# Altium schematic sheet ExoIMU_Connector.SchDoc(ExoIMU_Connector)
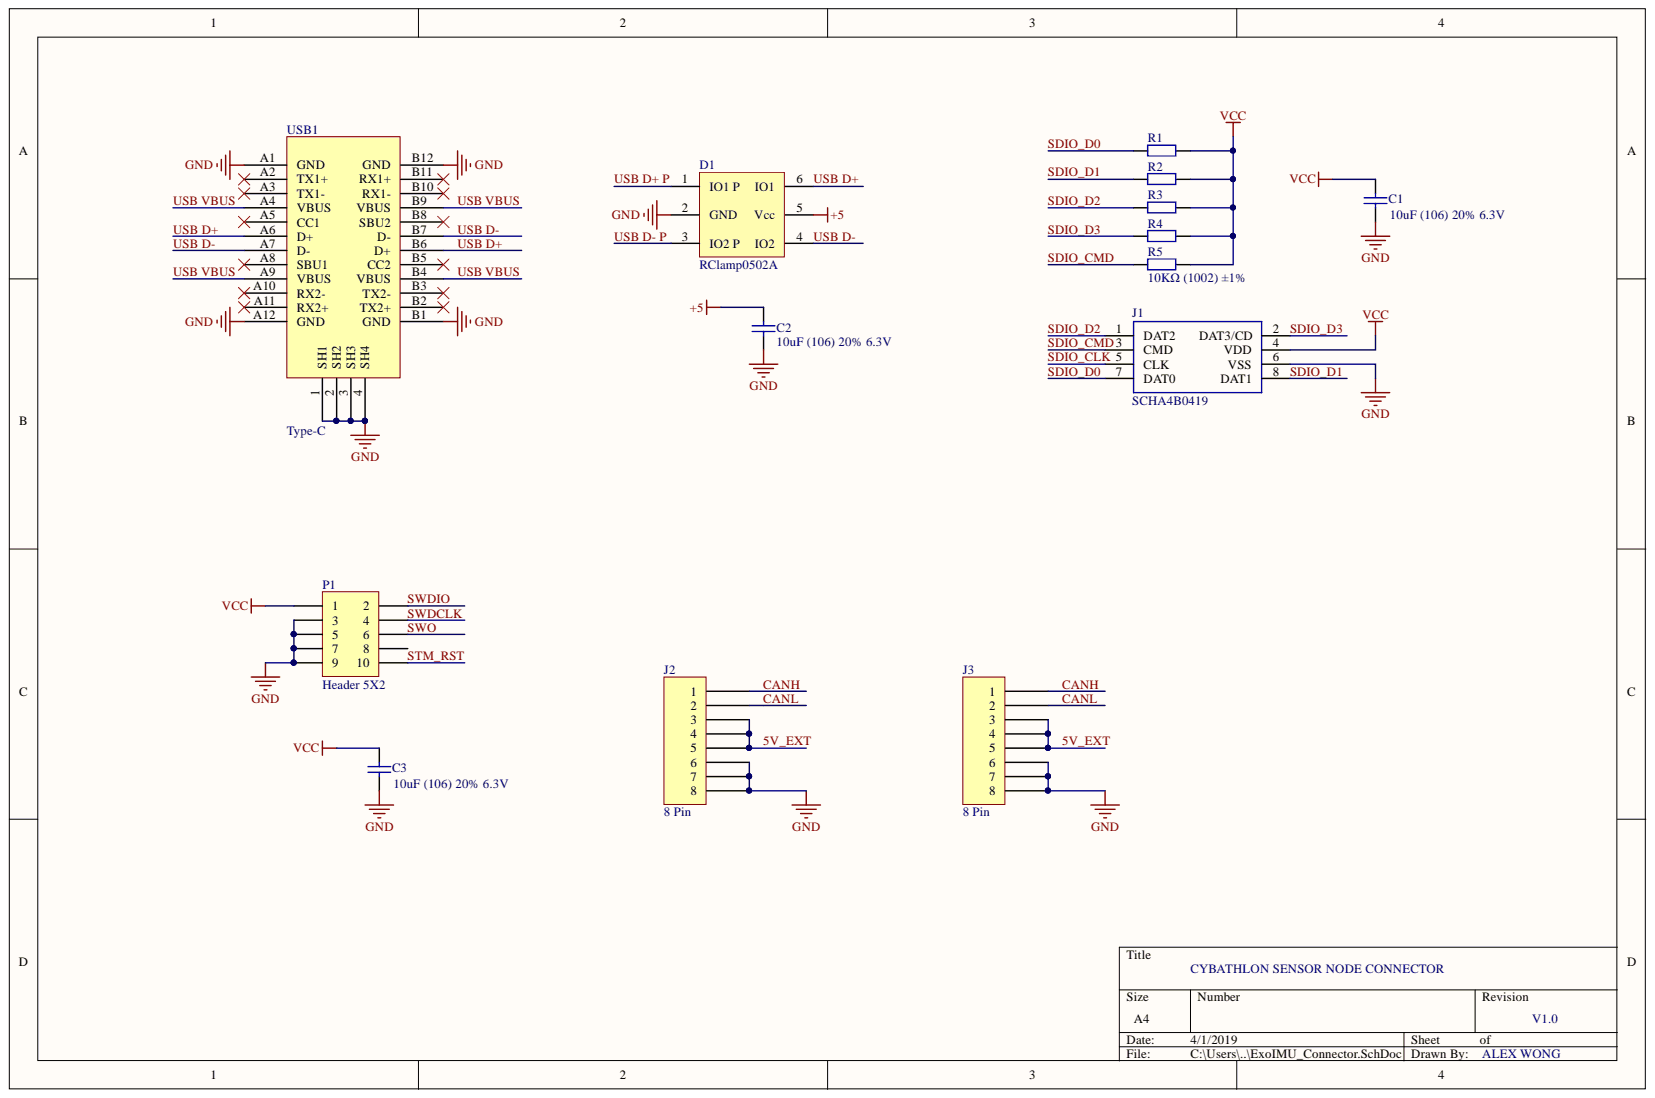
[diagram p0: ExoIMU_Connector.SchDoc]

## Parts
C1  10uF (106) 20% 6.3V  [0402_C]
C2  10uF (106) 20% 6.3V  [0402_C]
C3  10uF (106) 20% 6.3V  [0402_C]
D1  RClamp0502A  [SOTFL50P162X60-6N]
J1  SCHA4B0419  [SCHA4B0419]
J2  8 Pin  [JST SM08B-GHS-TB]
J3  8 Pin  [JST SM08B-GHS-TB]
P1  Header 5X2  [1.27 5x2 header swd]
R1  10KΩ (1002) ±1%  [0402_R]
R2  10KΩ (1002) ±1%  [0402_R]
R3  10KΩ (1002) ±1%  [0402_R]
R4  10KΩ (1002) ±1%  [0402_R]
R5  10KΩ (1002) ±1%  [0402_R]
USB1  Type-C  [Type-C]

## Nets
+5: C2.2 D1.5(Vcc)
GND: C1.1 C2.1 C3.1 D1.2(GND) J1.6(VSS) J2.6 J2.7 J2.8 J3.6 J3.7 J3.8 P1.3 P1.5 P1.7 P1.9 USB1.1(SH1) USB1.2(SH2) USB1.3(SH3) USB1.4(SH4) USB1.A1(GND) USB1.A12(GND) USB1.B1(GND) USB1.B12(GND)
VCC: C1.2 C3.2 J1.4(VDD) P1.1 R1.2 R2.2 R3.2 R4.2 R5.2
5V_EXT: J2.3 J2.4 J2.5 J3.3 J3.4 J3.5
CANH: J2.1 J3.1
CANL: J2.2 J3.2
SDIO_CLK: J1.5(CLK)
SDIO_CMD: J1.3(CMD) R5.1
SDIO_D0: J1.7(DAT0) R1.1
SDIO_D1: J1.8(DAT1) R2.1
SDIO_D2: J1.1(DAT2) R3.1
SDIO_D3: J1.2(DAT3/CD) R4.1
STM_RST: P1.10
SWDCLK: P1.4
SWDIO: P1.2
SWO: P1.6
USB D+: D1.6(IO1) USB1.A6(D+) USB1.B6(D+)
USB D+ P: D1.1(IO1_P)
USB D-: D1.4(IO2) USB1.A7(D-) USB1.B7(D-)
USB D- P: D1.3(IO2_P)
USB VBUS: USB1.A4(VBUS) USB1.A9(VBUS) USB1.B4(VBUS) USB1.B9(VBUS)

Not connected: P1 8; USB1 A2(TX1+) A3(TX1-) A5(CC1) A8(SBU1) A10(RX2-) A11(RX2+) B2(TX2+) B3(TX2-) B5(CC2) B8(SBU2) B10(RX1-) B11(RX1+)

## Library data of the parts
C1-C3 = 0402 10uF (106) 20% 6.3V: 贴片电容; SuppliersPartNumber: C15525; Supplier: LC; Package: 0402; Library Name: Miscellaneous Devices LC.IntLib; ComponentLink1URL: http://www.szlcsc.com/product/details_16204.html; ComponentLink1Description: 供应商链接; Component Kind: Standard
J1 = SCHA4B0419: Memory Card Connectors; Manufacturer_Name: ALPS; Mouser Part Number: 688-SCHA4B0419; Manufacturer_Part_Number: SCHA4B0419; Datasheet Link: https://componentsearchengine.com/Datasheets/1/SCHA4B0419.pdf; Component Kind: Standard; Library Name: alexLib.SchLib
P1 = Header 5X2: Header, 5-Pin, Dual row; Library Name: Miscellaneous Connectors.IntLib; LatestRevisionNote: Re-released for DXP Platform.; LatestRevisionDate: 17-Jul-2002; Component Kind: Standard; Publisher: Altium Limited
R1-R5 = 0402 10KΩ (1002) 1%: 贴片电阻; SuppliersPartNumber: C25744; Supplier: LC; Package: 0402; Library Name: RES.SchLib; ComponentLink1URL: http://www.szlcsc.com/product/details_26487.html; ComponentLink1Description: 供应商链接; Component Kind: Standard
D1 = RClamp0502A: Library Name: Hand component libary.SchLib; Component Kind: Standard
J2 J3 = 8 Pin: Library Name: Connectors.SchLib; Component Kind: Standard
USB1 = Type-C: Component Kind: Standard; Library Name: MCUcomponent.SCHLIB
